# Altium schematic sheet CURRENT_SOURCE.SchDoc
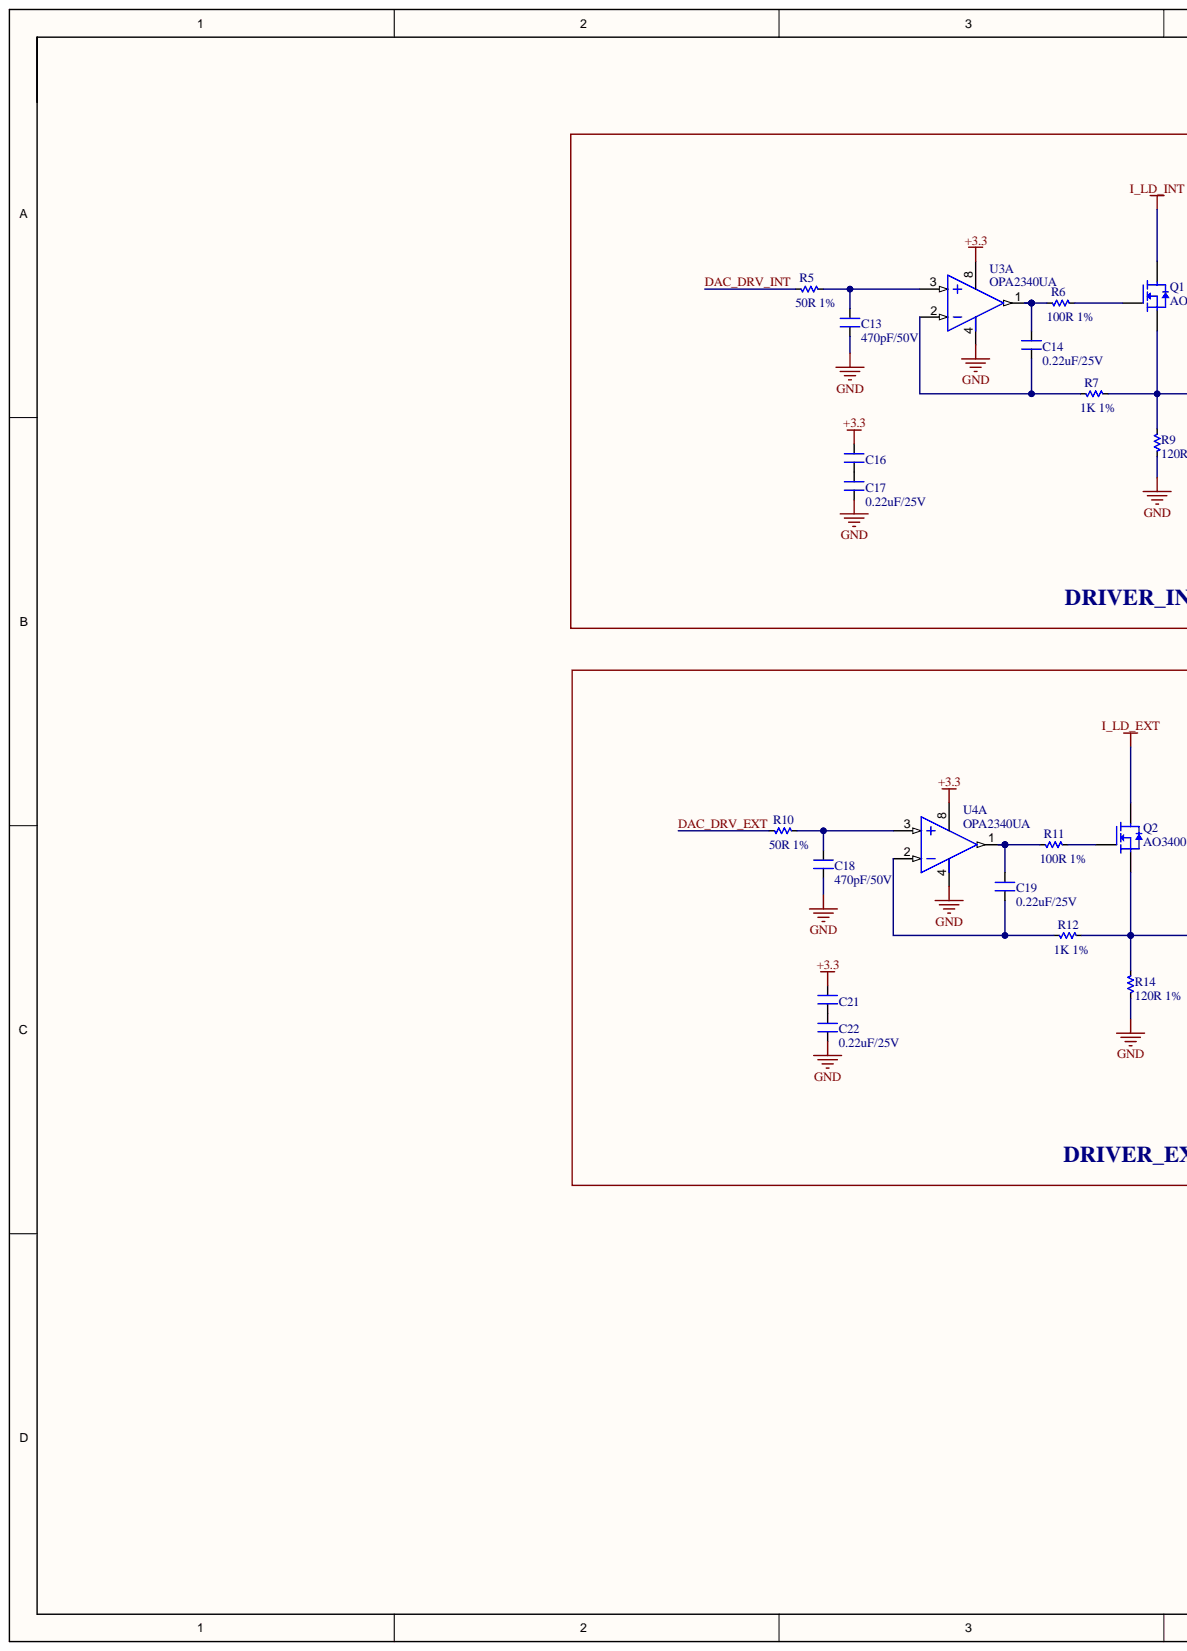
[diagram p0: CURRENT_SOURCE.SchDoc, left]
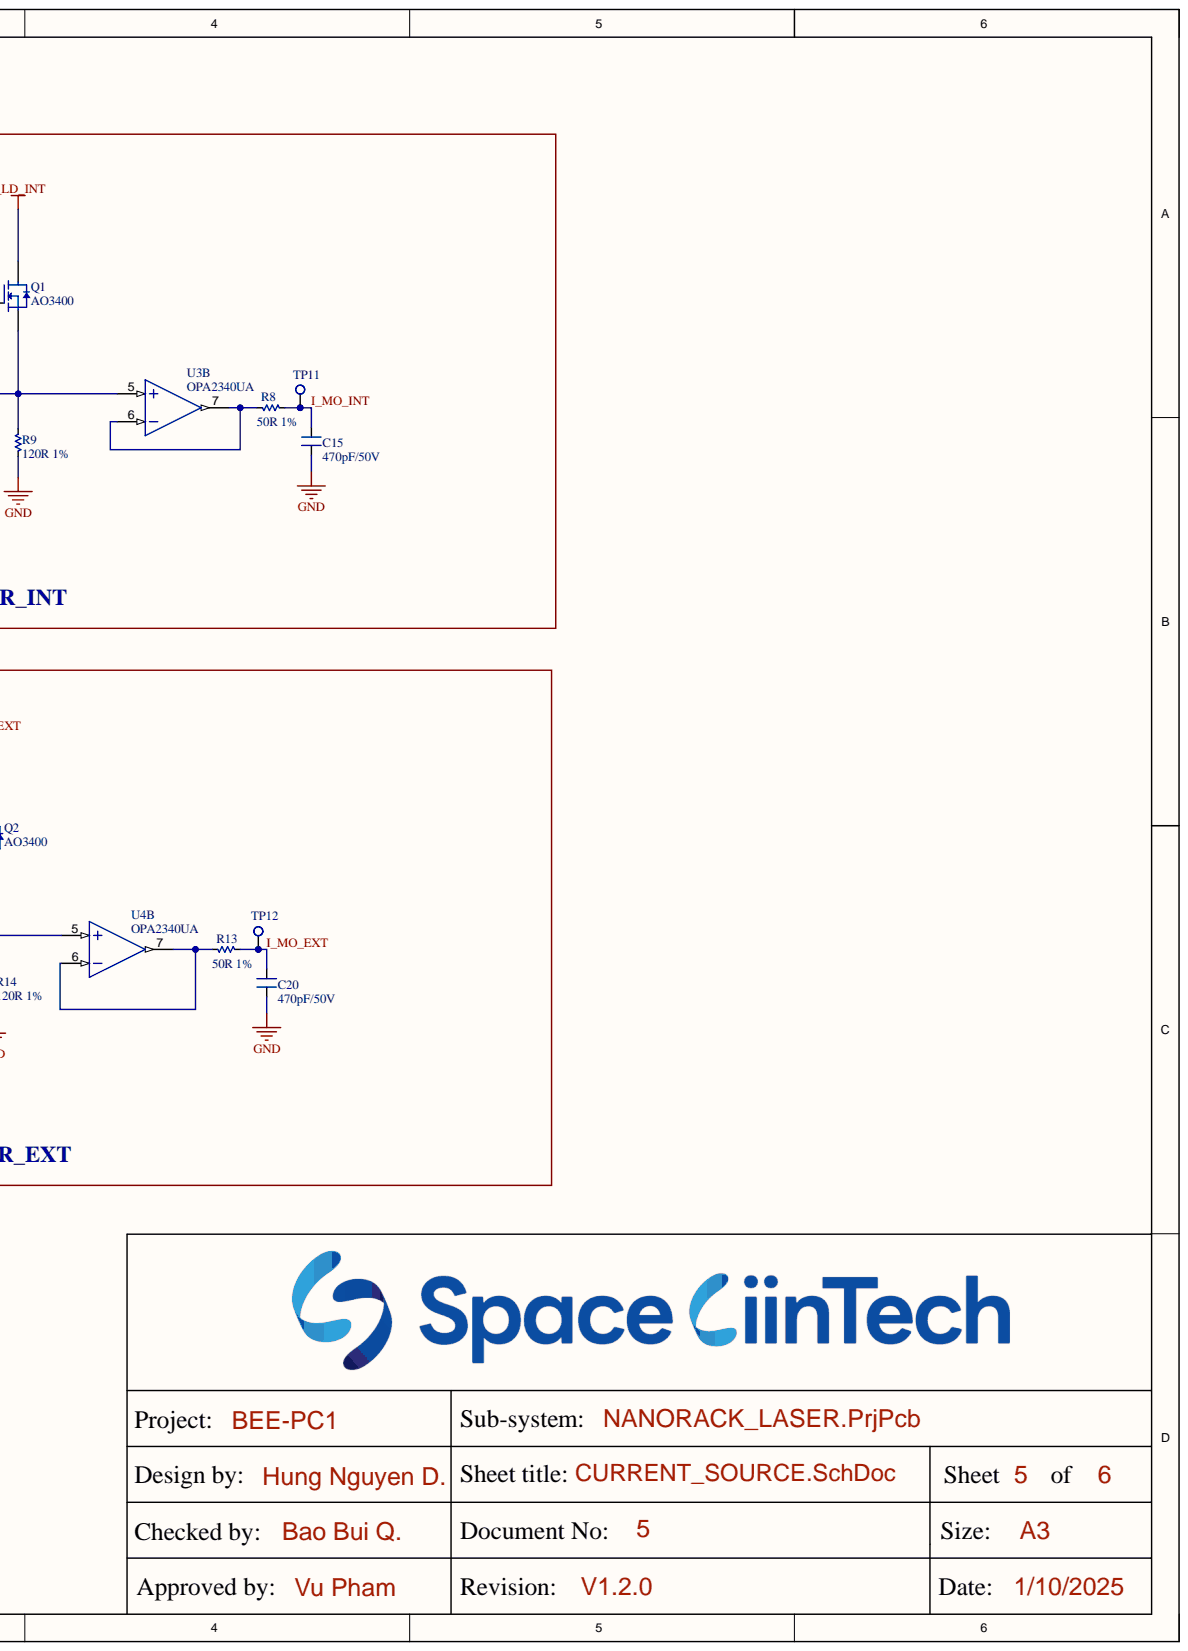
[diagram p1: CURRENT_SOURCE.SchDoc, right]

## Parts
C13  470pF/50V  [SM-0603]
C14  0.22uF/25V  [SM-0603]
C15  470pF/50V  [SM-0603]
C16  0.22uF/25V  [SM-0603]
C17  0.22uF/25V  [SM-0603]
C18  470pF/50V  [SM-0603]
C19  0.22uF/25V  [SM-0603]
C20  470pF/50V  [SM-0603]
C21  0.22uF/25V  [SM-0603]
C22  0.22uF/25V  [SM-0603]
Q1  AO3400  [SOT23-132]
Q2  AO3400  [SOT23-132]
R5  50R 1%  [RES-SMD-0603]
R6  100R 1%  [RES-SMD-0603]
R7  1K 1%  [RES-SMD-0603]
R8  50R 1%  [RES-SMD-0603]
R9  120R 1%  [RES-SMD-1206]
R10  50R 1%  [RES-SMD-0603]
R11  100R 1%  [RES-SMD-0603]
R12  1K 1%  [RES-SMD-0603]
R13  50R 1%  [RES-SMD-0603]
R14  120R 1%  [RES-SMD-1206]
TP11  Test_Point  [TESTPOINT]  (value not drawn)
TP12  Test_Point  [TESTPOINT]  (value not drawn)
U3  OPA2340UA  [SO8]  (parts A,B)
U4  OPA2340UA  [SO8]  (parts A,B)

## Nets
+3.3: C16.2 C21.2 U3.8 U4.8
GND: C13.1 C15.1 C17.1 C18.1 C20.1 C22.1 R9.1 R14.1 U3.4 U4.4
I_LD_EXT: Q2.3
I_LD_INT: Q1.3
DAC_DRV_EXT: R10.1
DAC_DRV_INT: R5.1
I_MO_EXT: C20.2 R13.2 TP12.1
I_MO_INT: C15.2 R8.2 TP11.1
NetC13_2: C13.2 R5.2 U3.3
NetC14_1: C14.1 R7.1 U3.2
NetC14_2: C14.2 R6.1 U3.1
NetC16_1: C16.1 C17.2
NetC18_2: C18.2 R10.2 U4.3
NetC19_1: C19.1 R12.1 U4.2
NetC19_2: C19.2 R11.1 U4.1
NetC21_1: C21.1 C22.2
NetQ1_1: Q1.1 R6.2
NetQ1_2: Q1.2 R7.2 R9.2 U3.5
NetQ2_1: Q2.1 R11.2
NetQ2_2: Q2.2 R12.2 R14.2 U4.5
NetR8_1: R8.1 U3.6 U3.7
NetR13_1: R13.1 U4.6 U4.7

## Library data of the parts
C13-C22 = CM: 0603; Value: 103; Component Kind: Standard; Library Name: Capacitors.SchLib
Q1 Q2 = FET-N: Component Kind: Standard; Library Name: Library.SchLib
R5-R8 R10-R14 = Res-SMD: 0603; Manufacturer: Generic Components; Class: Passive; Component Kind: Standard; Library Name: Library.SchLib; Published: 08/06/2000; Publisher: Altium Hobart Technology Centre
R9 = Res-SMD: 1206; Manufacturer: Generic Components; Class: Passive; Component Kind: Standard; Library Name: Library.SchLib; Published: 08/06/2000; Publisher: Altium Hobart Technology Centre
U3 U4 = LM358: 8SOIC; Value: LM358; Component Kind: Standard; Library Name: Library.SchLib
TP11 TP12 = Test_Point: Component Kind: Standard; Library Name: Library_HUNG.SchLib
